# Altium schematic sheet CV210506.SchDoc
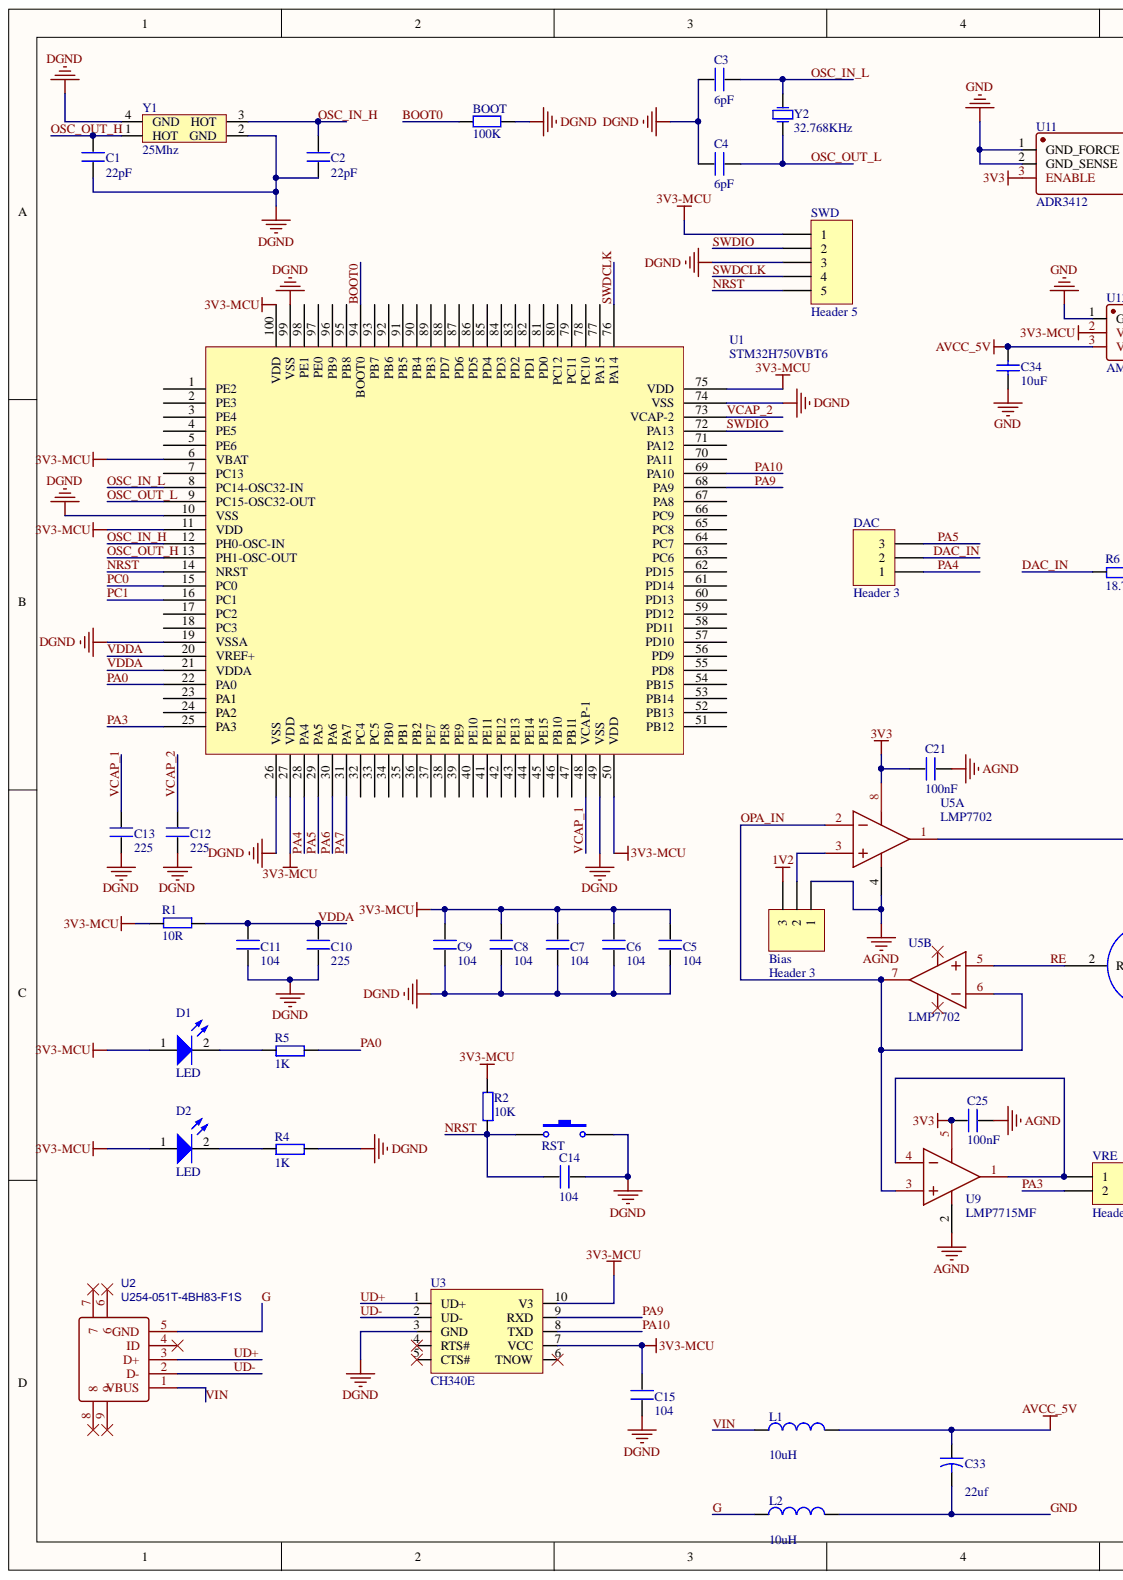
[diagram p0: CV210506.SchDoc, left]
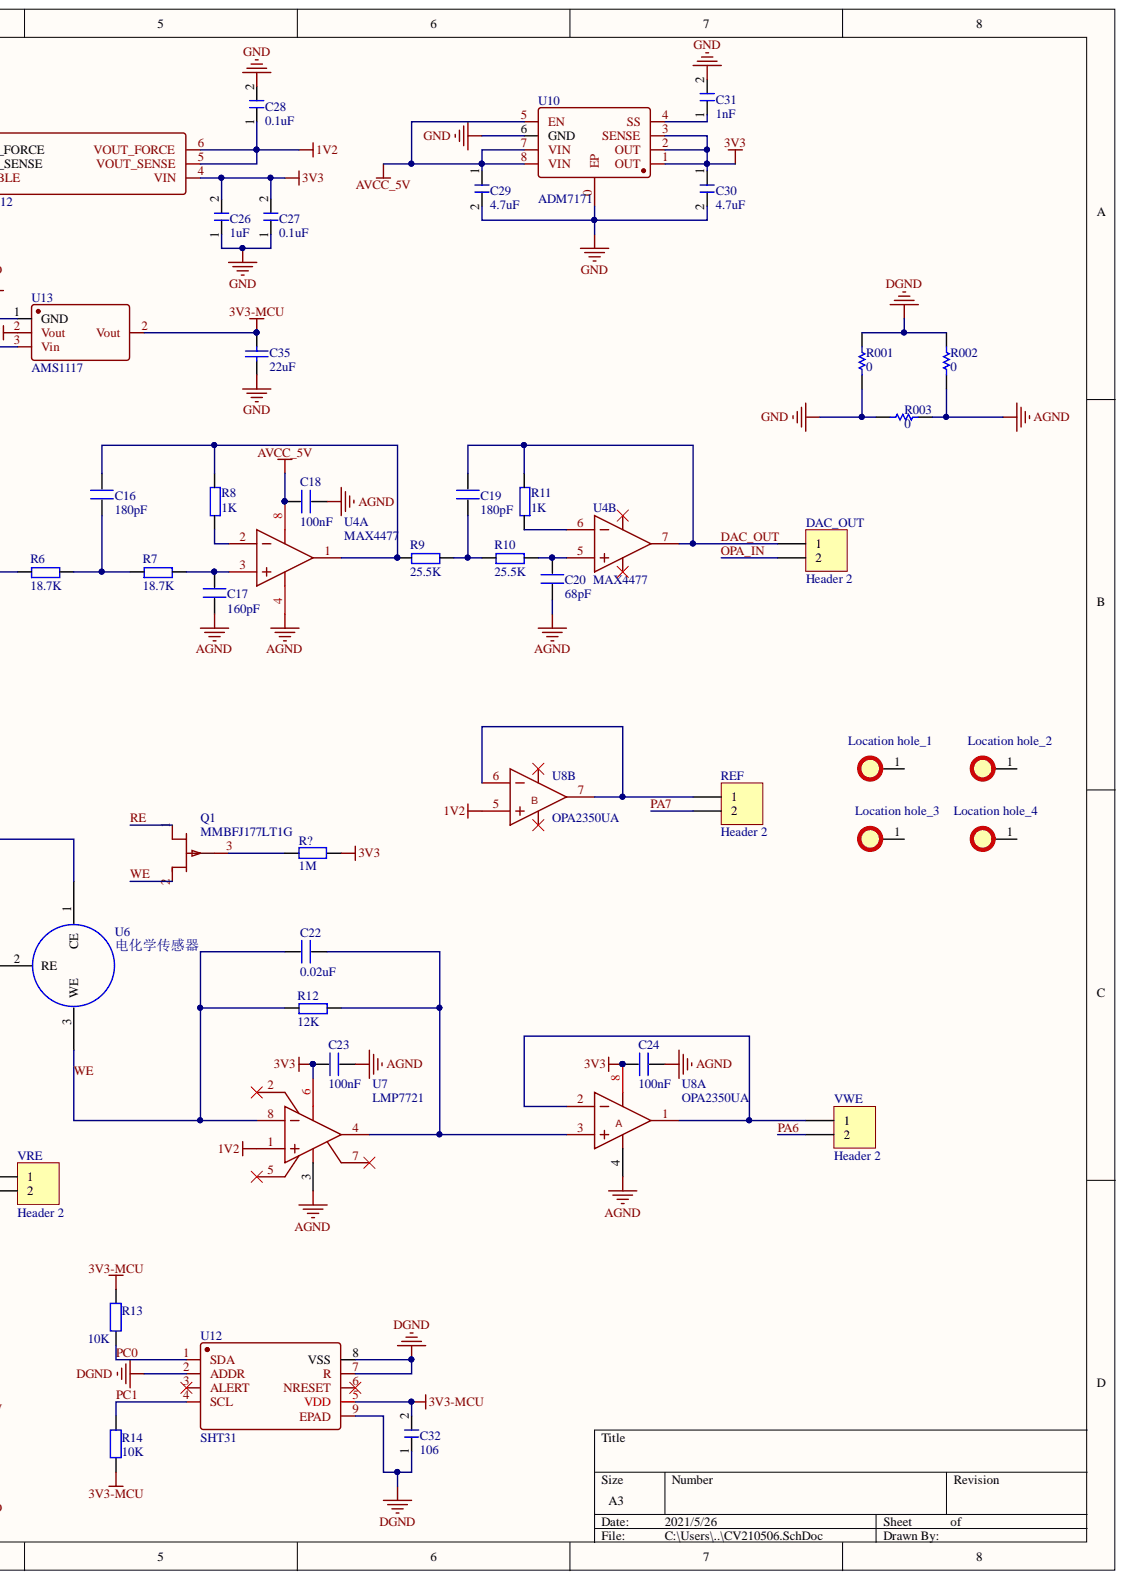
[diagram p1: CV210506.SchDoc, right]

## Parts
Bias  Header 3  [HDR1X3]
BOOT  100K  [RES_0805]
C1  22pF  [CAP_0805]
C2  22pF  [CAP_0805]
C3  6pF  [CAP_0805]
C4  6pF  [CAP_0805]
C5  104  [CAP_0805]
C6  104  [CAP_0805]
C7  104  [CAP_0805]
C8  104  [CAP_0805]
C9  104  [CAP_0805]
C10  225  [CAP_0805]
C11  104  [CAP_0805]
C12  225  [CAP_0805]
C13  225  [CAP_0805]
C14  104  [CAP_0805]
C15  104  [CAP_0805]
C16  180pF  [CAP_0805]
C17  160pF  [CAP_0805]
C18  100nF  [CAP_0805]
C19  180pF  [CAP_0805]
C20  68pF  [CAP_0805]
C21  100nF  [CAP_0805]
C22  0.02uF  [CAP_0805]
C23  100nF  [CAP_0805]
C24  100nF  [CAP_0805]
C25  100nF  [CAP_0805]
C26  1uF  [CAP_0805]
C27  0.1uF  [CAP_0805]
C28  0.1uF  [CAP_0805]
C29  4.7uF  [CAP_0805]
C30  4.7uF  [CAP_0805]
C31  1nF  [CAP_0805]
C32  106  [CAP_0805]
C33  value 22uf  [CAP_0805]  (hidden comment: Cap2)
C34  10uF  [CAP_0805]
C35  22uF  [CAP_0805]
D1  LED  [DIODE_0603]
D2  LED  [DIODE_0603]
DAC  Header 3  [HDR1X3]
DAC_OUT  Header 2  [HDR1X2]
L1  value 10uH  [RES_1206]  (hidden comment: Inductor)
L2  value 10uH  [RES_1206]  (hidden comment: Inductor)
Location_hole_1  Touch  [kong 3.5]  (value not drawn)
Location_hole_2  Touch  [kong 3.5]  (value not drawn)
Location_hole_3  Touch  [kong 3.5]  (value not drawn)
Location_hole_4  Touch  [kong 3.5]  (value not drawn)
Q1  MMBFJ177LT1G  [SOT23-3]
R001  0  [RES_0805]
R1  10R  [RES_0805]
R002  0  [RES_0805]
R2  10K  [RES_0805]
R003  0  [RES_0805]
R4  1K  [RES_0805]
R5  1K  [RES_0805]
R6  18.7K  [RES_0805]
R7  18.7K  [RES_0805]
R8  1K  [RES_0805]
R9  25.5K  [RES_0805]
R10  25.5K  [RES_0805]
R11  1K  [RES_0805]
R12  12K  [RES_0805]
R13  10K  [RES_0805]
R14  10K  [RES_0805]
R?  value 1M  [RES_0805]  (hidden comment: 1K)
REF  Header 2  [HDR1X2]
RST  SW-PB  [key3*3]  (value not drawn)
SWD  Header 5  [HDR1X5]
U1  STM32H750VBT6  [LQFP-100]
U2  U254-051T-4BH83-F1S  [USB]
U3  CH340E  [MSOP-10_L3.0-W3.0-P0.50-LS5.0-BL]
U4  MAX4477  [uMAX-8]  (parts A,B)
U5  LMP7702  [SOIC-8]  (parts A,B)
U6  电化学传感器  [HDR1X3]
U7  LMP7721  [SOIC-8]
U8  OPA2350UA  [SOIC-8]  (parts A,B)
U9  LMP7715MF  [SOT-23-5]
U10  ADM7171  [LFCSP-8]
U11  ADR3412  [SOT-23-6]
U12  SHT31  [DFN-8_EP_3x3mm]
U13  AMS1117  [SOT-223]
VRE  Header 2  [HDR1X2]
VWE  Header 2  [HDR1X2]
Y1  25Mhz  [SMD 3225]
Y2  32.768KHz  [SMD 3215-2P 32.768K]

## Nets
1V2: Bias.3 C28.1 U7.1 U8.5 U11.5(VOUT_SENSE) U11.6(VOUT_FORCE)
3V3: C21.2 C23.2 C24.2 C25.2 C26.2 C27.2 C30.1 R?.1 U5.8 U7.6 U8.8 U9.5 U10.1(OUT) U10.2(OUT) U10.3(SENSE) U11.3(ENABLE) U11.4(VIN)
3V3-MCU: C5.2 C6.2 C7.2 C8.2 C9.2 C15.1 C32.2 C35.2 D1.1 D2.1 R1.2 R2.2 R13.2 R14.1 SWD.1 U1.6(VBAT) U1.11(VDD) U1.27(VDD) U1.50(VDD) U1.75(VDD) U1.100(VDD) U3.7(VCC) U3.10(V3) U12.5(VDD) U13.2
AGND: Bias.1 C17.1 C18.1 C20.1 C21.1 C23.1 C24.1 C25.1 R002.2 R003.1 U4.4 U5.4 U7.3 U8.4 U9.2
AVCC_5V: C18.2 C29.1 C33.1 C34.2 L1.2 U4.8 U10.5(EN) U10.7(VIN) U10.8(VIN) U13.3
DGND: BOOT.2 C1.1 C2.1 C3.1 C4.1 C5.1 C6.1 C7.1 C8.1 C9.1 C10.1 C11.1 C12.1 C13.1 C14.2 C15.2 C32.1 R001.1 R002.1 R4.2 RST.2 SWD.3 U1.10(VSS) U1.19(VSSA) U1.26(VSS) U1.49(VSS) U1.74(VSS) U1.99(VSS) U3.3(GND) U12.2(ADDR) U12.7(R) U12.8(VSS) U12.9(EPAD) Y1.2(GND) Y1.4(GND)
BOOT0: BOOT.1 U1.94(BOOT0)
DAC_IN: DAC.2 R6.1
DAC_OUT: C19.2 DAC_OUT.1 R11.2 U4.7
G: L2.1 U2.5(GND)
GND: C26.1 C27.1 C28.2 C29.2 C30.2 C31.2 C33.2 C34.1 C35.1 L2.2 R001.2 R003.2 U10.0(EP) U10.6(GND) U11.1(GND_FORCE) U11.2(GND_SENSE) U13.1
NRST: C14.1 R2.1 RST.1 SWD.5 U1.14(NRST)
OPA_IN: DAC_OUT.2 U5.2 U5.6 U5.7 U9.3
OSC_IN_H: C2.2 U1.12(PH0-OSC-IN) Y1.3(HOT)
OSC_IN_L: C3.2 U1.8(PC14-OSC32-IN) Y2.2
OSC_OUT_H: C1.2 U1.13(PH1-OSC-OUT) Y1.1(HOT)
OSC_OUT_L: C4.2 U1.9(PC15-OSC32-OUT) Y2.1
PA0: R5.2 U1.22(PA0)
PA3: U1.25(PA3) VRE.2
PA4: DAC.1 U1.28(PA4)
PA5: DAC.3 U1.29(PA5)
PA6: U1.30(PA6) VWE.2
PA7: REF.2 U1.31(PA7)
PA9: U1.68(PA9) U3.9(RXD)
PA10: U1.69(PA10) U3.8(TXD)
PC0: R13.1 U1.15(PC0) U12.1(SDA)
PC1: R14.2 U1.16(PC1) U12.4(SCL)
RE: Q1.1 U5.5 U6.2
SWDCLK: SWD.4 U1.76(PA14)
SWDIO: SWD.2 U1.72(PA13)
UD+: U2.3(D+) U3.1(UD+)
UD-: U2.2(D-) U3.2(UD-)
VCAP_1: C13.2 U1.48(VCAP-1)
VCAP_2: C12.2 U1.73(VCAP-2)
VDDA: C10.2 C11.2 R1.1 U1.20(VREF+) U1.21(VDDA)
VIN: L1.1 U2.1(VBUS)
WE: C22.2 Q1.2 R12.1 U6.3 U7.8
NetBias_2: Bias.2 U5.3
NetC16_1: C16.1 R6.2 R7.1
NetC16_2: C16.2 R8.2 R9.1 U4.1
NetC17_2: C17.2 R7.2 U4.3
NetC19_1: C19.1 R9.2 R10.1
NetC20_2: C20.2 R10.2 U4.5
NetC22_1: C22.1 R12.2 U7.4 U8.3
NetC31_1: C31.1 U10.4(SS)
NetD1_2: D1.2 R5.1
NetD2_2: D2.2 R4.1
NetQ1_3: Q1.3 R?.2
NetR8_1: R8.1 U4.2
NetR11_1: R11.1 U4.6
NetREF_1: REF.1 U8.6 U8.7
NetU5_1: U5.1 U6.1
NetU8_1: U8.1 U8.2 VWE.1
NetU9_1: U9.1 U9.4 VRE.1

Not connected: Location_hole_1 1; Location_hole_2 1; Location_hole_3 1; Location_hole_4 1; U1 1(PE2) 2(PE3) 3(PE4) 4(PE5) 5(PE6) 7(PC13) 17(PC2) 18(PC3) 23(PA1) 24(PA2) 32(PC4) 33(PC5) 34(PB0) 35(PB1) 36(PB2) 37(PE7) 38(PE8) 39(PE9) 40(PE10) 41(PE11) 42(PE12) 43(PE13) 44(PE14) 45(PE15) 46(PB10) 47(PB11) 51(PB12) 52(PB13) 53(PB14) 54(PB15) 55(PD8) 56(PD9) 57(PD10) 58(PD11) 59(PD12) 60(PD13) 61(PD14) 62(PD15) 63(PC6) 64(PC7) 65(PC8) 66(PC9) 67(PA8) 70(PA11) 71(PA12) 77(PA15) 78(PC10) 79(PC11) 80(PC12) 81(PD0) 82(PD1) 83(PD2) 84(PD3) 85(PD4) 86(PD5) 87(PD6) 88(PD7) 89(PB3) 90(PB4) 91(PB5) 92(PB6) 93(PB7) 95(PB8) 96(PB9) 97(PE0) 98(PE1); U2 4(ID) 6 7 8 9; U3 4(RTS#) 5(CTS#) 6(TNOW); U7 2 5 7; U12 3(ALERT) 6(NRESET)

## Library data of the parts
Bias DAC = Header 3: Header, 3-Pin; Component Kind: Standard; LatestRevisionDate: 17-Jul-2002; LatestRevisionNote: Re-released for DXP Platform.; Library Name: Miscellaneous Connectors.IntLib; Signal Integrity: Connector; Publisher: Altium Limited
BOOT R13 R14 R? = Res2: Resistor; Component Kind: Standard; LatestRevisionDate: 17-Jul-2002; LatestRevisionNote: Re-released for DXP Platform.; PackageReference: AXIAL-0.4; Published: 8-Jun-2000; Signal Integrity: Res; Simulation: RESISTOR; Publisher: Altium Limited
  BOOT: Library Name: Miscellaneous Devices.IntLib; PackageDescription: Axial Device, Thru-Hole; 2 Leads; 0.4 in Pin Spacing; Value: 100K
  R13 R14: Value: 10K
  R13 R14 R?: Library Name: myintlib.SchLib
C1-C25 = 电容: Component Kind: Standard; Library Name: STM32H743.SchLib
C26-C32 = Cap: Component Kind: Standard; Library Name: WzyControl1.SchLib
C33 = Cap2: Capacitor; Component Kind: Standard; Library Name: Miscellaneous Devices.IntLib; PackageDescription: Capacitor; 2 Leads; PackageReference: CAPR5-4X5; Published: 23-Sep-2002; Signal Integrity: Cap; Simulation: CAP; Publisher: Altium Limited
C34 C35 = Cap: Capacitor; Component Kind: Standard; LatestRevisionDate: 17-Jul-2002; LatestRevisionNote: Re-released for DXP Platform.; Library Name: Miscellaneous Devices.IntLib; PackageDescription: Radial Cap, Thru-Hole; 2 Leads; 0.3 in Pin Spacing; PackageReference: RAD-0.3; Published: 8-Jun-2000; Signal Integrity: Cap; Simulation: CAP; Publisher: Altium Limited
DAC_OUT REF VRE VWE = Header 2: Header, 2-Pin; Component Kind: Standard; LatestRevisionDate: 17-Jul-2002; LatestRevisionNote: Re-released for DXP Platform.; Library Name: Miscellaneous Connectors.IntLib; Signal Integrity: Connector; Publisher: Altium Limited
L1 L2 = Inductor: Code_IEC: 1005; Code_JEITA: 0402; Component Kind: Standard; LatestRevisionDate: 29-May-2009; LatestRevisionNote: IPC-7351 Footprint Added.; Library Name: Miscellaneous Devices.IntLib; PackageDescription: Chip Inductor; PackageReference: 0402-A; Published: 8-Jun-2000; Signal Integrity: Inductor; Simulation: INDUCTOR; Publisher: Altium Limited
  L2: Description: Inductor
R001 R002 R003 = Res1: Resistor; Component Kind: Standard; Library Name: Miscellaneous Devices.IntLib; Value: 0
R1 R2 R4-R12 = 电阻: Component Kind: Standard; Library Name: STM32H743.SchLib
RST = SW-PB: Switch; Component Kind: Standard; LatestRevisionDate: 17-Jul-2002; LatestRevisionNote: Re-released for DXP Platform.; Library Name: STM32H743.SchLib; PackageDescription: Switch; 2 Leads; PackageReference: SPST-2; Published: 24-Mar-1999; Publisher: Altium Limited
SWD = Header 5: Header, 5-Pin; Component Kind: Standard; LatestRevisionDate: 17-Jul-2002; LatestRevisionNote: Re-released for DXP Platform.; Library Name: Miscellaneous Connectors.IntLib; Signal Integrity: Connector; Publisher: Altium Limited
U2 = U254-051T-4BH83-F1S: BOM_Manufacturer: XKB Enterprise; Contributor: LCSC; BOM_Manufacturer Part: U254-051T-4BH83-F1S; BOM_Supplier: LCSC; BOM_Supplier Part: C397452; Component Kind: Standard; spicePre: U; spiceSymbolName: U254-051T-4BH83-F1S
U3 = CH340-E: Component Kind: Standard; Library Name: WzyControl1.SchLib
U10 = ADM7172: Component Kind: Standard; Library Name: WzyControl1.SchLib
Y1 = XTAL_3225: 3225贴片无源晶振; Component Kind: Standard; Library Name: STM32H743核心板封装库.IntLib
Y2 = XTAL: 普通晶振; Component Kind: Standard; LatestRevisionDate: 17-Jul-2002; LatestRevisionNote: Re-released for DXP Platform.; Library Name: STM32H743核心板封装库.IntLib; Published: 8-Jun-2000; Publisher: Altium Limited
D1 D2 = LED: Component Kind: Standard; Description: LED; Library Name: STM32H743.SchLib
Location_hole_1-Location_hole_4 = Touch: Component Kind: Standard; Library Name: Sean.SchLib
Q1 = MMBFJ177LT1G: Component Kind: Standard; Library Name: WzyControl1.SchLib
U1 = STM32H750VBT6: Component Kind: Standard; Library Name: STM32H743.SchLib
U4 = MAX4477: Component Kind: Standard; Library Name: WzyControl1.SchLib
U5 = LMP7702: Component Kind: Standard; Library Name: WzyControl1.SchLib
U6 = 电化学传感器: Component Kind: Standard; Library Name: WzyControl1.SchLib
U7 = LMP7721: Component Kind: Standard; Library Name: WzyControl1.SchLib
U8 = OPA2350UA: Component Kind: Standard; Library Name: WzyControl1.SchLib
U9 = LMP7715MF: Component Kind: Standard; Library Name: WzyControl1.SchLib
U11 = ADR3412: Component Kind: Standard; Library Name: WzyControl1.SchLib
U12 = SHT31: Component Kind: Standard; Library Name: WzyControl1.SchLib
U13 = AMS1117: Component Kind: Standard; Library Name: WzyControl1.SchLib
